# Altium schematic sheet Gate 2 Trigger & Accent CV.SchDoc("Gate 2 Trigger & Accent CV")
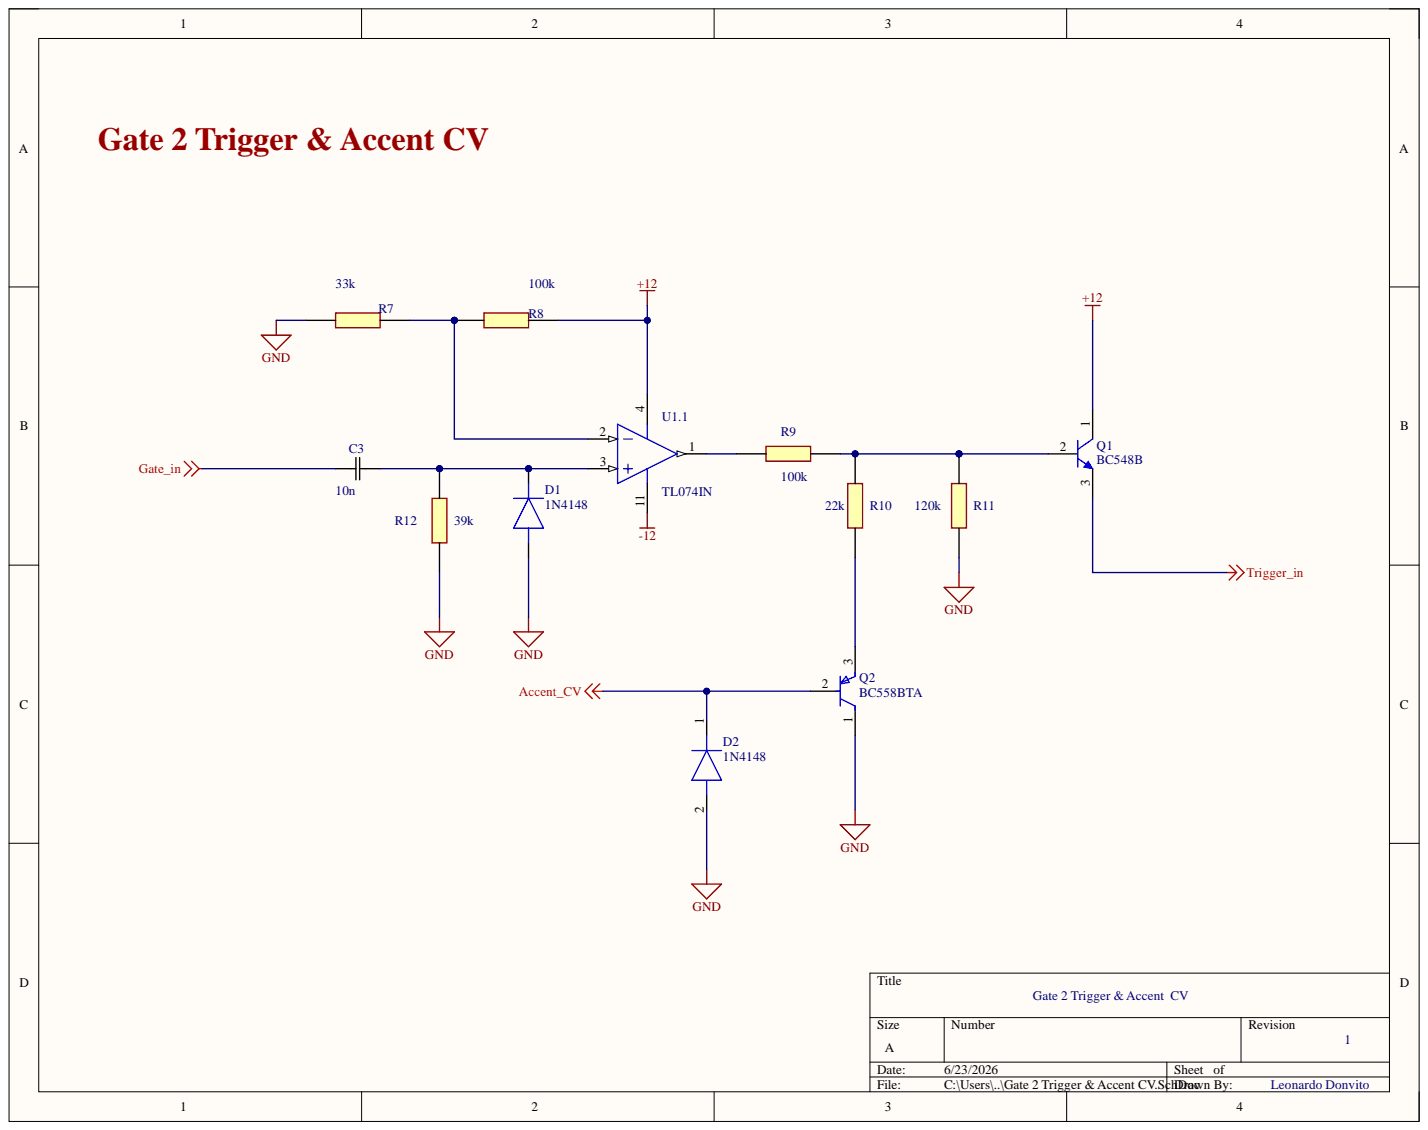
[diagram p0: Gate 2 Trigger & Accent CV.SchDoc]

## Parts
C3  value 10n  [CAP_C430C_AX_X7R_KEM]  (hidden comment: C430C106K3R5TA7200)
D1  1N4148  [DIOAD1068W53L380D172]
D2  1N4148  [DIOAD1068W53L380D172]
Q1  BC548B  [ONSC-TO-92-3-29-11]
Q2  BC558BTA  [FP-135AR-MFG]
R7  value 33k  [RESAD1590W60L630D240]  (hidden comment: MFR-25FTF52-600K)
R8  value 100k  [RESAD1590W60L630D240]  (hidden comment: MFR-25FTF52-600K)
R9  value 100k  [RESAD1590W60L630D240]  (hidden comment: MFR-25FTF52-600K)
R10  value 22k  [RESAD1590W60L630D240]  (hidden comment: MFR-25FTF52-600K)
R11  value 120k  [RESAD1590W60L630D240]  (hidden comment: MFR-25FTF52-600K)
R12  value 39k  [RESAD1590W60L630D240]  (hidden comment: MFR-25FTF52-600K)
U1  TL074IN  [N0014A]  (part .1)

## Nets
+12: Q1.1 R8.1 U1.4
-12: U1.11
Accent_CV: D2.1 Q2.2
Gate_in: C3.1
GND: D1.2 D2.2 Q2.1 R7.2 R11.2 R12.1
Trigger_in: Q1.3
NetC3_2: C3.2 D1.1 R12.2 U1.3
NetQ1_2: Q1.2 R9.1 R10.1 R11.1
NetQ2_3: Q2.3 R10.2
NetR7_1: R7.1 R8.2 U1.2
NetR9_2: R9.2 U1.1

## Library data of the parts
C3 = CMP-001-00093-1: 10nF ceramic capacitor; Mfr_Name: Kemet; Component Kind: Standard; Component Type: Standard; Design Item ID: C430C106K3R5TA7200; Pins: 2; Source: C430C106K3R5TA7200.SchLib; Library Name: EMEA - Politecnico di Torino - PoliTek Music
D1 D2 = CMP-004-00032-1: Small Signal Diode; Manufacturer_Name: onsemi; Arrow Part Number: 1N4148; Arrow Price/Stock: https://www.arrow.com/en/products/1n4148/on-semiconductor?region=europe; Component Kind: Standard; Component Type: Standard; Datasheet Link: https://www.onsemi.com/pub/Collateral/1N914-D.PDF; Design Item ID: 1N4148; Manufacturer_Part_Number: 1N4148; Mouser Part Number: 512-1N4148; Mouser Price/Stock: https://www.mouser.co.uk/ProductDetail/onsemi-Fairchild/1N4148?qs=i4Fj9T%2FoRm8RMUhj5DeFQg%3D%3D; Pins: 2; Source: SamacSys.SchLib; Library Name: EMEA - Politecnico di Torino - PoliTek Music
Q1 = CMP-013-00036-5: Bulk Through Hole NPN Single Bipolar (BJT) Transistor 200 @ 2mA 5V 100mA 500mW 300MHz; Case/Package: TO-92; Component Kind: Standard; Frequency: 300MHz; Max Operating Temperature: 150°C; Min Operating Temperature: -55°C; Mounting Technology: Through Hole; Pins: 3; Library Name: EMEA - Politecnico di Torino - PoliTek Music
Q2 = CMP-00278-84408946-1: BC558BTA Small Signal Bipolar Transistor, 0.1A I(C), 30V V(BR)CEO, 1-Element, PNP, Silicon, TO-92; Collector Base Voltage (VCBO): -30V; Collector Emitter Saturation Voltage: -250mV; Current Rating: -100mA; Element Configuration: Single; Emitter Base Voltage (VEBO): -5V; Frequency: 150MHz; hFE Min: 110; Max Frequency: 10MHz; Max Junction Temperature (Tj): 150°C; Max Power Dissipation: 500mW; Number of Elements: 1; Case/Package: TO-92; Collector Emitter Breakdown Voltage: 30V; Collector Emitter Voltage (VCEO): -30V; Component Kind: Standard; Contact Plating: Tin; Gain Bandwidth Product: 150MHz; Height: 5.33mm; Lead Free: Lead Free; Length: 5.2mm; Library Name: Altium Content Vault; Max Breakdown Voltage: 30V; Max Collector Current: -100mA; Max Operating Temperature: 150°C; Min Operating Temperature: -65°C; Mount: Through Hole; NexarPartId: 84408946; Number of Pins: 3; Polarity: PNP; Power Dissipation: 500mW; REACH SVHC: No SVHC; RoHS: Compliant; Schedule B: 8541210080; Transition Frequency: 150MHz; Voltage Rating (DC): -30V; Weight: 240mg; Width: 4.19mm
R7-R12 = CMP-009-00182-2: Metal Film Resistors - Through Hole; Manufacturer_Name: YAGEO; Component Kind: Standard; Component Type: Standard; Datasheet Link: https://www.mouser.sg/datasheet/2/447/YAGEO_MFR_datasheet_2023v3-3324391.pdf; Design Item ID: MFR-25FTF52-600K; Manufacturer_Part_Number: MFR-25FTF52-600K; Mouser Part Number: 603-MFR-25FTF52-600K; Mouser Price/Stock: https://www.mouser.co.uk/ProductDetail/YAGEO/MFR-25FTF52-600K?qs=xZ%2FP%252Ba9zWqYtTZdHR75SUg%3D%3D; Pins: 2; Source: SamacSys.SchLib; Library Name: EMEA - Politecnico di Torino - PoliTek Music
U1 = CMP-0017-00871-2: Quad Low-Noise JFET-Input General-Purpose Operational Amplifier, 7 to 36 V, -40 to 85 degC, 14-pin DIP (N14), Pb-Free (RoHS); Manufacturer: Texas Instruments; CMRR(Min)(dB): 70; GBW(Typ)(MHz): 3; IIB(Max)(pA): 200; Iq per channel(Max)(mA): 2.5; Number of Channels: 4; Offset Drift(Typ)(uV/C): 18; Rating: Military; Slew Rate(Typ)(V/us): 13; Sub Family: General Purpose Amplifier, High Supply Voltage Amplifier (>=30V); Total Supply Voltage(Max)(+5V is 5, +/-5V is 10): 36; Total Supply Voltage(Min)(+5V is 5, +/-5V is 10): 7; Vio (25C)(Max)(mV): 10; Code_JEDEC: MS-001; Component Kind: Standard; ComponentLink1Description: Manufacturer URL; ComponentLink1URL: http://www.ti.com/; ComponentLink2Description: Datasheet; ComponentLink2URL: http://www.ti.com/lit/ds/symlink/tl074.pdf; ComponentLink3Description: Package Specification; ComponentLink3URL: http://www.ti.com/lit/ml/mpdi002c/mpdi002c.pdf; DatasheetVersion: SLOS080J; Eco-Plan: Pb-Free (RoHS); Library Name: Altium Content Vault; Mounting Technology: Through Hole; PackageDescription: 14-Pin Plastic In-Line, Body 19.305 x 6.35 mm, Pitch 2.54 mm; PackageReference: N0014A; PackageVersion: 4040049/E, 12/2002; PartNumber: TL074IN; Vn at 1kHz(Typ)(nV/rtHz): 18
